# Altium schematic sheet SDCard.SchDoc(SDCard)
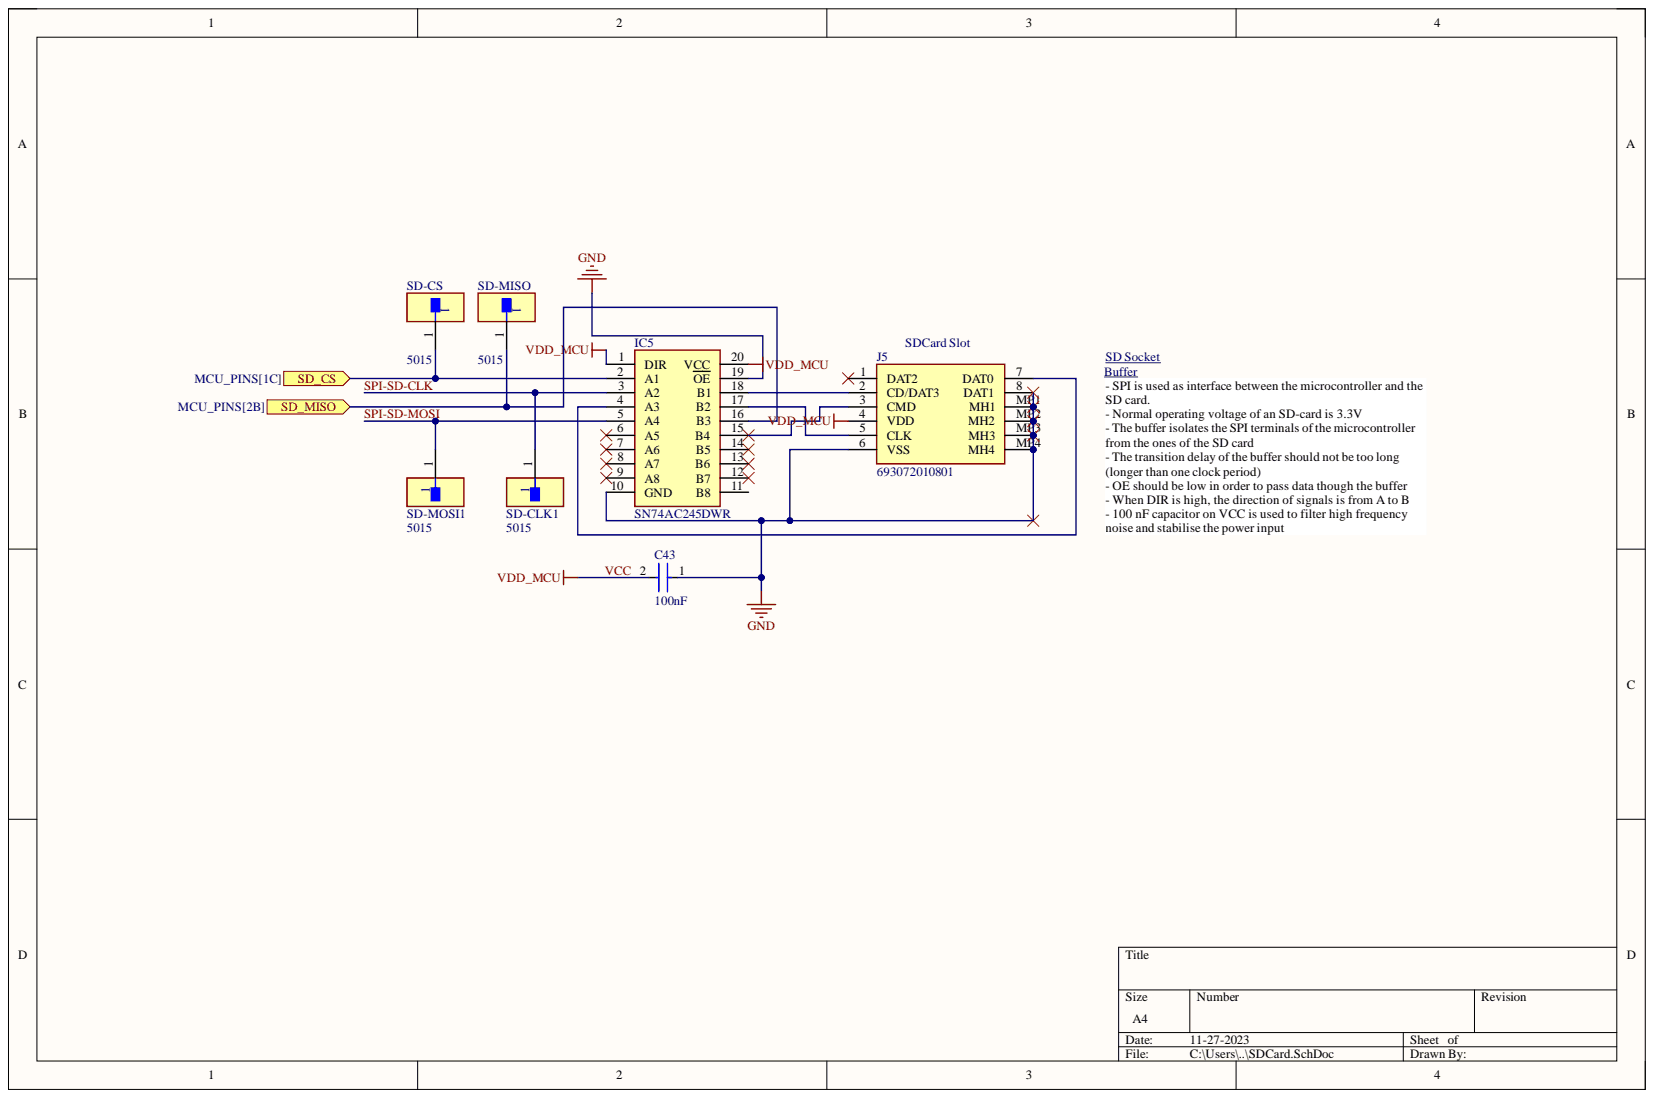
[diagram p0: SDCard.SchDoc]

## Parts
C43  100nF  [FP-C0603C-CF-MFG]
IC5  SN74AC245DWR  [SOIC127P1030X265-20N]
J5  693072010801  [693072010801]
SD-CLK1  5015  [FP-5015-MFG]
SD-CS  5015  [FP-5015-MFG]
SD-MISO  5015  [FP-5015-MFG]
SD-MOSI1  5015  [FP-5015-MFG]

## Ports
SD_CS, SD_MISO

## Nets
GND: C43.1 IC5.10(GND) IC5.19(OE) J5.6(VSS) J5.8(DAT1) J5.MP1(MH1) J5.MP2(MH2) J5.MP3(MH3) J5.MP4(MH4)
SD_CS: IC5.2(A1) SD-CS.1 ; port SD_CS
SD_MISO: IC5.16(B3) SD-MISO.1 ; port SD_MISO
SPI-SD-CLK: IC5.3(A2) SD-CLK1.1
SPI-SD-MOSI: IC5.5(A4) SD-MOSI1.1
VCC: C43.2 IC5.1(DIR) IC5.20(VCC) J5.4(VDD)
NetIC5_4: IC5.4(A3) J5.7(DAT0)
NetIC5_15: IC5.15(B4) J5.3(CMD)
NetIC5_17: IC5.17(B2) J5.5(CLK)
NetIC5_18: IC5.18(B1) J5.2(CD/DAT3)

Not connected: IC5 6(A5) 7(A6) 8(A7) 9(A8) 11(B8) 12(B7) 13(B6) 14(B5); J5 1(DAT2)

## Library data of the parts
C43 = CMP-2006-03061-2: CAP CER 0.1UF 50V X7R 0603; Manufacturer: KEMET; Manufacturer Part Number: C0603C104K5RACTU; Automotive Grade: Grade 1; Capacitance: 0.10 μF; Material: Ceramic; Temperature Coefficient: 30ppm/°C; Tolerance: 0.1; Value: 0.10 μF; Automotive: Yes; Category: Cap; Component Kind: Standard; ComponentLink2Description: Datasheet; ComponentLink2URL: https://api.kemet.com/component-edge/download/datasheet/C0603C100J5GACAUTO.pdf; Device Class L1: Passive Components; Device Class L2: Capacitors; Device Class L3: Ceramic Capacitors; Digikey Description: CAP CER 0.1UF 50V X7R 0603; Height: 0.87mm; Lead Free: Yes; Library Name: Altium Content Vault; Octopart: https://octopart.com/c0603c104k5ractu-kemet-139244; Package: C0603C-CF; RoHS: TRUE; Temperature: -55°C to +125°C; Temperature Characteristic: X7R; Temperature Range High: +125°C; Temperature Range Low: -55°C; Voltage Rating: 50 V
IC5 = SN74AC245DWR: Integrated Circuit; Manufacturer_Name: Texas Instruments; Arrow Part Number: SN74AC245DWR; Arrow Price/Stock: https://www.arrow.com/en/products/sn74ac245dwr/texas-instruments?region=nac; Component Kind: Standard; Datasheet Link: http://www.ti.com/lit/gpn/sn74ac245; Height: 2.65mm; Manufacturer_Part_Number: SN74AC245DWR; Mouser Part Number: 595-SN74AC245DWR; Mouser Price/Stock: https://www.mouser.co.uk/ProductDetail/Texas-Instruments/SN74AC245DWR?qs=dQtLEVC3WoZnKak8xOt2vA%3D%3D
J5 = 693072010801: Connector; Manufacturer_Name: Wurth Elektronik; Component Kind: Standard; Datasheet Link: https://www.we-online.de/katalog/datasheet/693072010801.pdf; Height: 1.95mm; Manufacturer_Part_Number: 693072010801; Mouser Part Number: 710-693072010801; Mouser Price/Stock: https://www.mouser.co.uk/ProductDetail/Wurth-Elektronik/693072010801?qs=7gQLVZk5cPmw4uU1mAcUFg%3D%3D
SD-CLK1 SD-CS SD-MISO SD-MOSI1 = CMP-2000-05183-2: PC TEST POINT MINIATURE; Manufacturer: keystone; Conn Orientation: Straight; Number Of Contacts: 1; Automotive: No; Category: Conn; Component Kind: Standard; ComponentLink2Description: Datasheet; ComponentLink2URL: http://www.keyelco.com/product-pdf.cfm?p=1353; Contact Material: Silver; Device Class L1: Connectors; Device Class L2: unset; Device Class L3: unset; Digikey Description: PC TEST POINT MINIATURE; Height: 1.65mm; Lead Free: Yes; Library Name: Altium Content Vault; Manufacturer Part Number: 5015; Octopart: https://octopart.com/5015-keystone-3822; Package: 5015; RoHS: TRUE
